# Altium schematic sheet Meter011.SchDoc
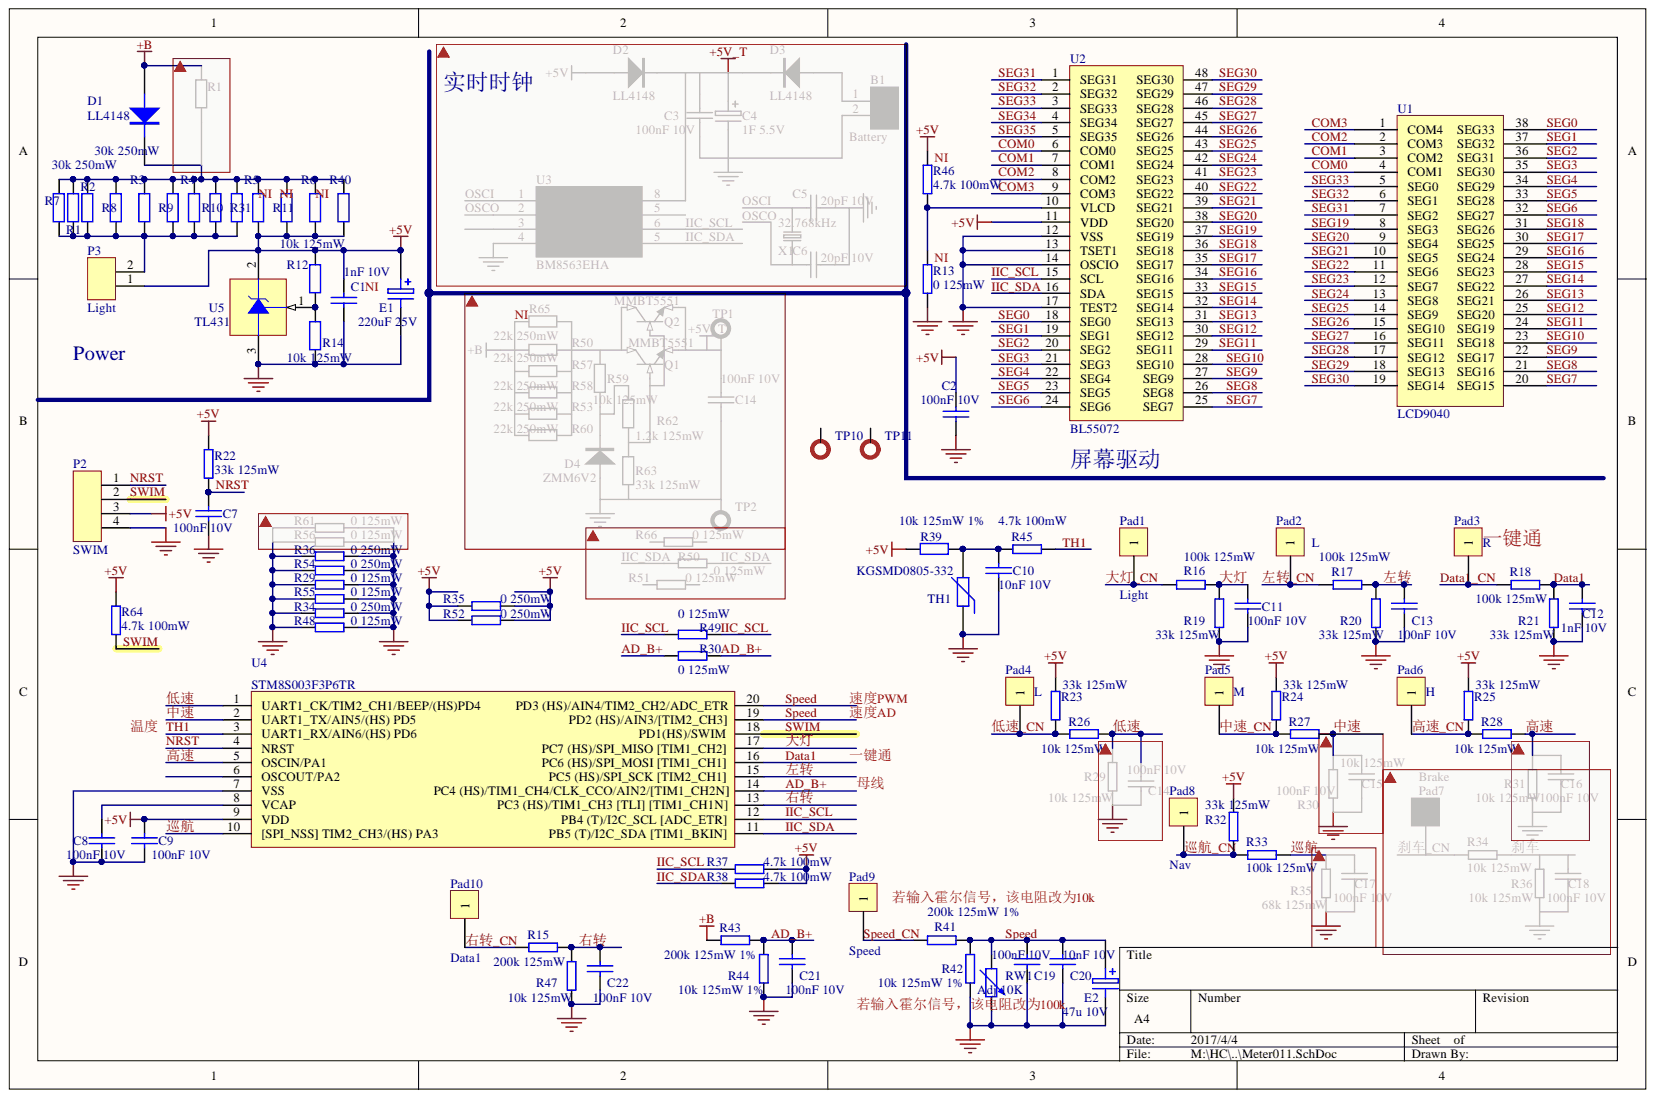
[diagram p0: Meter011.SchDoc]

## Parts
C1  1nF 10V  [0805]
C2  100nF 10V  [0603]
C7  100nF 10V  [0805]
C8  100nF 10V  [0603]
C9  100nF 10V  [0603]
C10  10nF 10V  [0603]
C11  100nF 10V  [0603]
C12  1nF 10V  [0603]
C13  100nF 10V  [0603]
C19  100nF 10V  [0603]
C20  10nF 10V  [0603]
C21  100nF 10V  [0603]
C22  100nF 10V  [0805]
D1  LL4148  [MELF-D3516-1406]
E1  220uF 25V  Pressure: 25V  [RB.1/.2]
E2  47u 10V  [RB.1/.2]
P2  SWIM  [SIP4]
P3  Light  [sip2]
Pad1  Light  [PAD]
Pad2  L  [PAD]
Pad3  R  [PAD]
Pad4  L  [PAD]
Pad5  M  [PAD]
Pad6  H  [PAD]
Pad8  Nav  [PAD]
Pad9  Speed  [PAD]
Pad10  Data1  [PAD]
R1  30k 250mW  [1206]
R2  30k 250mW  [1206]
R3  30k 250mW  [1206]
R4  30k 250mW  [1206]
R5  30k 250mW  [1206]
R6  30k 250mW  [1206]
R7  30k 250mW  [1206]
R8  30k 250mW  [1206]
R9  30k 250mW  [1206]
R10  30k 250mW  [1206]
R11  30k 250mW  [1206]
R12  10k 125mW  [0805]
R13  0 125mW  [0805]
R14  10k 125mW  [0805]
R15  200k 125mW  [0805]
R16  100k 125mW  [0805]
R17  100k 125mW  [0805]
R18  100k 125mW  [0805]
R19  33k 125mW  [0805]
R20  33k 125mW  [0805]
R21  33k 125mW  [0805]
R22  33k 125mW  [0805]
R23  33k 125mW  [0805]
R24  33k 125mW  [0805]
R25  33k 125mW  [0805]
R26  10k 125mW  [0805]
R27  10k 125mW  [0805]
R28  10k 125mW  [0805]
R29  0 125mW  [0805]
R30  0 125mW  [0805]
R31  30k 250mW  [1206]
R32  33k 125mW  [0805]
R33  100k 125mW  [0805]
R34  0 250mW  [1206]
R35  0 250mW  [1206]
R36  0 250mW  [1206]
R37  4.7k 100mW  [0603]
R38  4.7k 100mW  [0603]
R39  10k 125mW 1%  [0805]
R40  30k 250mW  [1206]
R41  200k 125mW 1%  [0805]
R42  10k 125mW 1%  [0805]
R43  200k 125mW 1%  [0805]
R44  10k 125mW 1%  [0805]
R45  4.7k 100mW  [0603]
R46  4.7k 100mW  [0603]
R47  10k 125mW  [0805]
R48  0 125mW  [0805]
R49  0 125mW  [0805]
R52  0 250mW  [1206]
R54  0 250mW  [1206]
R55  0 125mW  [1206]
R64  4.7k 100mW  [0603]
RW1  Adj 10K  [ADJRes]
TH1  KGSMD0805-332  [0805]
TP10  (no value)  [PCB_MarkPoint]
TP11  (no value)  [PCB_MarkPoint]
U1  LCD9040
U2  BL55072  [TSSOP-48]
U4  STM8S003F3P6TR  [SSOP20]
U5  TL431  [SOT-23]

## Nets
+5V: C1.1 C2.1 C9.1 E1.1 P2.3 P3.1 R5.1 R6.1 R11.1 R12.1 R22.2 R23.1 R24.1 R25.1 R32.1 R35.1 R35.2 R37.1 R38.1 R39.2 R40.1 R46.1 R52.1 R52.2 R64.1 U2.11(VDD) U4.9(VDD) U5.2
+B: D1.1 R43.2
GND: C1.2 C2.2 C7.2 C8.2 C9.2 C10.2 C11.2 C12.2 C13.2 C19.2 C20.2 C21.2 C22.2 E1.2 E2.2 P2.4 R13.2 R14.2 R19.2 R20.2 R21.2 R29.1 R29.2 R34.1 R34.2 R36.1 R36.2 R42.2 R44.2 R47.2 R48.1 R48.2 R54.1 R54.2 R55.1 R55.2 RW1.2 TH1.1 U2.12(VSS) U2.13(TSET1) U2.14(OSCIO) U2.17(TEST2) U4.7(VSS) U5.3
AD_B+: C21.1 R30.1 R30.2 R43.1 R44.1 U4.14
COM0: U1.4(COM1) U2.6(COM0)
COM1: U1.3(COM2) U2.7(COM1)
COM2: U1.2(COM3) U2.8(COM2)
COM3: U1.1(COM4) U2.9(COM3)
DATA1: C12.1 R18.1 R21.1 U4.16(PC6_(HS)/SPI_MOSI_[TIM1_CH1])
DATA1_CN: Pad3.1 R18.2
IIC_SCL: R37.2 R49.1 R49.2 U2.15(SCL) U4.12(PB4_(T)/I2C_SCL_[ADC_ETR])
IIC_SDA: R38.2 U2.16(SDA) U4.11(PB5_(T)/I2C_SDA_[TIM1_BKIN])
NRST: C7.1 P2.1 R22.1 U4.4(NRST)
SEG0: U1.38(SEG33) U2.18(SEG0)
SEG1: U1.37(SEG32) U2.19(SEG1)
SEG2: U1.36(SEG31) U2.20(SEG2)
SEG3: U1.35(SEG30) U2.21(SEG3)
SEG4: U1.34(SEG29) U2.22(SEG4)
SEG5: U1.33(SEG28) U2.23(SEG5)
SEG6: U1.32(SEG27) U2.24(SEG6)
SEG7: U1.20(SEG15) U2.25(SEG7)
SEG8: U1.21(SEG16) U2.26(SEG8)
SEG9: U1.22(SEG17) U2.27(SEG9)
SEG10: U1.23(SEG18) U2.28(SEG10)
SEG11: U1.24(SEG19) U2.29(SEG11)
SEG12: U1.25(SEG20) U2.30(SEG12)
SEG13: U1.26(SEG21) U2.31(SEG13)
SEG14: U1.27(SEG22) U2.32(SEG14)
SEG15: U1.28(SEG23) U2.33(SEG15)
SEG16: U1.29(SEG24) U2.34(SEG16)
SEG17: U1.30(SEG25) U2.35(SEG17)
SEG18: U1.31(SEG26) U2.36(SEG18)
SEG19: U1.8(SEG3) U2.37(SEG19)
SEG20: U1.9(SEG4) U2.38(SEG20)
SEG21: U1.10(SEG5) U2.39(SEG21)
SEG22: U1.11(SEG6) U2.40(SEG22)
SEG23: U1.12(SEG7) U2.41(SEG23)
SEG24: U1.13(SEG8) U2.42(SEG24)
SEG25: U1.14(SEG9) U2.43(SEG25)
SEG26: U1.15(SEG10) U2.44(SEG26)
SEG27: U1.16(SEG11) U2.45(SEG27)
SEG28: U1.17(SEG12) U2.46(SEG28)
SEG29: U1.18(SEG13) U2.47(SEG29)
SEG30: U1.19(SEG14) U2.48(SEG30)
SEG31: U1.7(SEG2) U2.1(SEG31)
SEG32: U1.6(SEG1) U2.2(SEG32)
SEG33: U1.5(SEG0) U2.3(SEG33)
SEG34: U2.4(SEG34)
SEG35: U2.5(SEG35)
SPEED: C19.1 C20.1 E2.1 R41.1 R42.1 RW1.1 U4.19(PD2_(HS)/AIN3/[TIM2_CH3]) U4.20(PD3_(HS)/AIN4/TIM2_CH2/ADC_ETR)
SPEED_CN: Pad9.1 R41.2
SWIM: P2.2 R64.2 U4.18(PD1(HS)/SWIM)
TH1: R45.1 U4.3(UART1_RX/AIN6/(HS)_PD6)
中速: R27.1 U4.2(UART1_TX/AIN5/(HS)_PD5)
中速_CN: Pad5.1 R24.2 R27.2
低速: R26.1 U4.1(UART1_CK/TIM2_CH1/BEEP/(HS)PD4)
低速_CN: Pad4.1 R23.2 R26.2
右转: C22.1 R15.1 R47.1 U4.13(PC3_(HS)/TIM1_CH3_[TLI]_[TIM1_CH1N])
右转_CN: Pad10.1 R15.2
大灯: C11.1 R16.1 R19.1 U4.17(PC7_(HS)/SPI_MISO_[TIM1_CH2])
大灯_CN: Pad1.1 R16.2
巡航: R33.1 U4.10([SPI_NSS]_TIM2_CH3/(HS)_PA3)
巡航_CN: Pad8.1 R32.2 R33.2
左转: C13.1 R17.1 R20.1 U4.15(PC5_(HS)/SPI_SCK_[TIM2_CH1])
左转_CN: Pad2.1 R17.2
高速: R28.1 U4.5(OSCIN/PA1)
高速_CN: Pad6.1 R25.2 R28.2
NetC8_1: C8.1 U4.8(VCAP)
NetC10_1: C10.1 R39.1 R45.2 TH1.2
NetD1_2: D1.2 R1.2 R2.2 R3.2 R4.2 R5.2 R6.2 R7.2 R8.2 R9.2 R10.2 R11.2 R31.2 R40.2
NetP3_2: P3.2 R1.1 R2.1 R3.1 R4.1 R7.1 R8.1 R9.1 R10.1 R31.1
NetR12_2: R12.2 R14.1 U5.1
NetR13_1: R13.1 R46.2 U2.10(VLCD)
+5V_T:
N00014:

Not connected: TP10 1; TP11 1; U4 6(OSCOUT/PA2)

## Library data of the parts
C1 C2 C7-C13 C19-C22 = CAP: Capacitor; Component Kind: Standard
D1 = DIODE: : 1A 1.1V; Component Kind: Standard; Description: Diode
E1 = ELECTRO1: Electrolytic Capacitor; Component Kind: Standard
E2 = ELECTRO1: Electrolytic Capacitor; Pressure: 25V; Component Kind: Standard
P2 = CON4: Component Kind: Standard; Library Name: whlSCHLIB.SchLib
P3 = CON2: Component Kind: Standard; Library Name: whlSCHLIB.SchLib
Pad1-Pad6 Pad8-Pad10 = CON1: Connector; Component Kind: Standard
R1-R12 R14 R31 R39 R40 = Res2: Resistor; Component Kind: Standard; LatestRevisionDate: 17-Jul-2002; LatestRevisionNote: Re-released for DXP Platform.; PackageReference: AXIAL-0.4; Published: 8-Jun-2000; Publisher: Altium Limited
R13 R15-R30 R32-R38 R41-R49 R52 R54 R55 R64 = WHLRES: Component Kind: Standard; Library Name: whlSCHLIB.SchLib
RW1 = Res Adj2: Variable Resistor; Set Position: 0.5; Value: 4.7K; Component Kind: Standard; LatestRevisionDate: 17-Jul-2002; LatestRevisionNote: Re-released for DXP Platform.; Library Name: Miscellaneous Devices.IntLib; PackageDescription: Axial Device, Thru-Hole; 2 Leads; 0.6 in Pin Spacing; PackageReference: AXIAL-0.6; Published: 8-Jun-2000; Signal Integrity: Res Adj; Simulation: VRES; Publisher: Altium Limited
TH1 = Res Varistor: Varistor (Voltage-Sensitive Resistor); Component Kind: Standard; LatestRevisionDate: 04-Mar-2005; LatestRevisionNote: PCB Footprint replaced.; Library Name: Miscellaneous Devices.IntLib; PackageReference: 6-0805; Published: 24-Mar-1999; Publisher: Altium Limited
TP10 TP11 = TEST-1: Component Kind: Standard; Library Name: BMS_V1.2.SCHLIB
U4 = STM8S003F3P6: Component Kind: Standard; Library Name: 音效器.SCHLIB
U5 = TL430CLP: Adjustable Shunt Regulator; Code_JEDEC: TO-226-AA; Component Kind: Standard; ComponentLink1Description: Manufacturer Link; ComponentLink1URL: http://www.ti.com/; DatasheetVersion: Mar-1988; Library Name: TI Power Mgt Voltage Regulator.IntLib; PackageDescription: TO, Flat Index; 3 In-Line, Axial Leads; Body Dia. 4.8mm; Leads 0.56 x 0.41 mm (max); PackageReference: LP03; PackageVersion: Nov-2001; Published: 15-Apr-2002; Publisher: Altium Limited
U1 = LCD9040: Component Kind: Standard; Library Name: SchMylib1.SCHLIB
U2 = BL55072: Component Kind: Standard; Library Name: DB_v0.1.SCHLIB
